# Altium schematic sheet Main.SchDoc
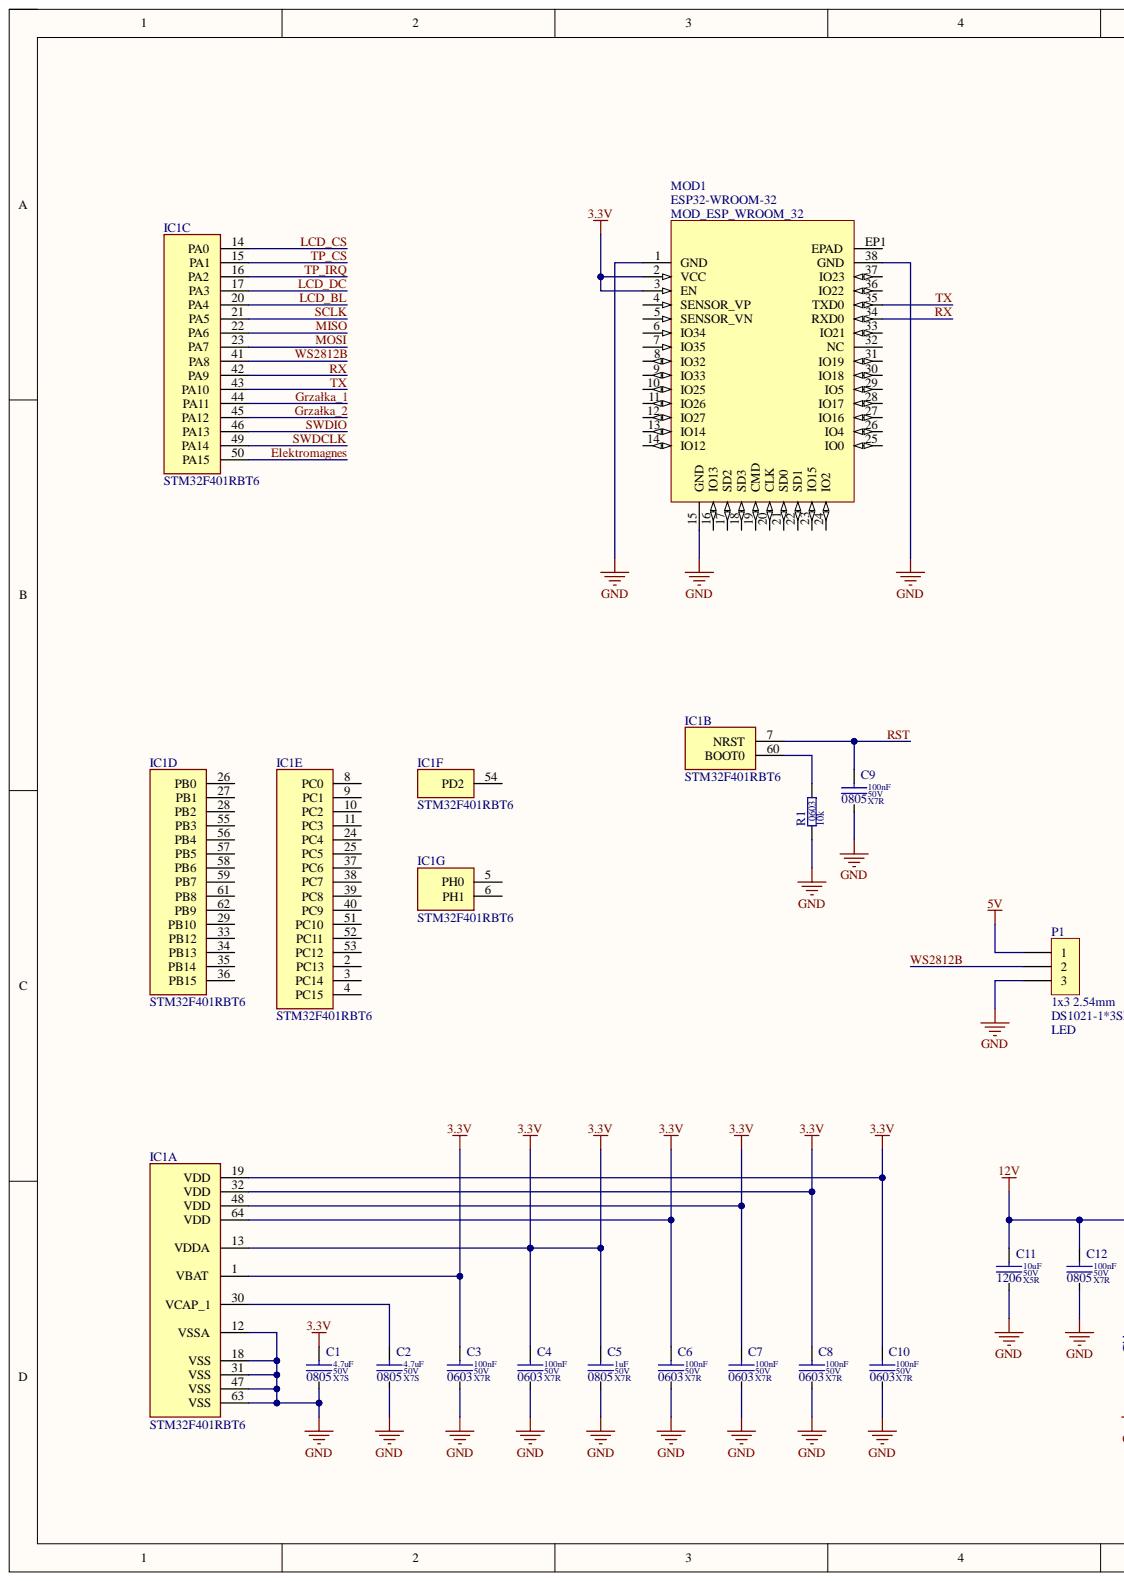
[diagram p0: Main.SchDoc, left]
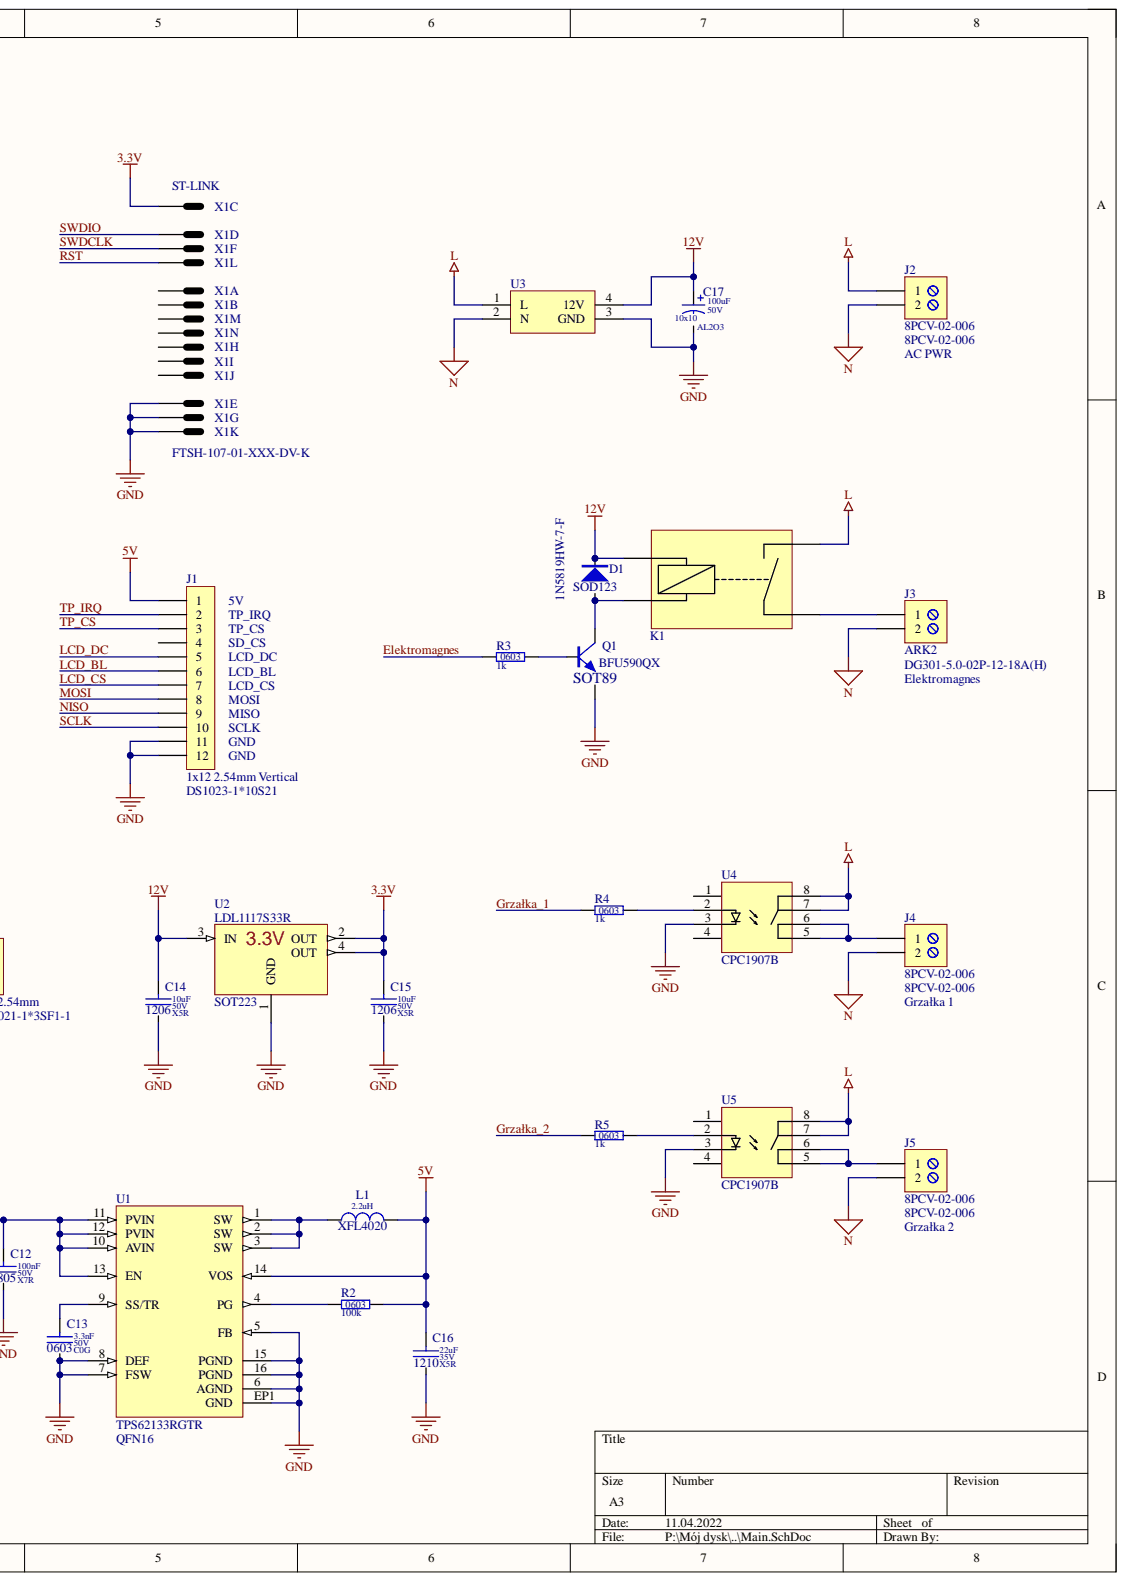
[diagram p1: Main.SchDoc, right]

## Parts
C1  value 4.7uF  Dielectric: X7S  SCH_Footprint: 0805  Voltage: 50V  [C_0805_2012]  (hidden comment: Ceramic X7S)
C2  value 4.7uF  Dielectric: X7S  SCH_Footprint: 0805  Voltage: 50V  [C_0805_2012]  (hidden comment: Ceramic X7S)
C3  value 100nF  Dielectric: X7R  SCH_Footprint: 0603  Voltage: 50V  [C_0603_1608]  (hidden comment: Ceramic X7R)
C4  value 100nF  Dielectric: X7R  SCH_Footprint: 0603  Voltage: 50V  [C_0603_1608]  (hidden comment: Ceramic X7R)
C5  value 1uF  Dielectric: X7R  SCH_Footprint: 0805  Voltage: 50V  [C_0805_2012]  (hidden comment: Ceramic X7R)
C6  value 100nF  Dielectric: X7R  SCH_Footprint: 0603  Voltage: 50V  [C_0603_1608]  (hidden comment: Ceramic X7R)
C7  value 100nF  Dielectric: X7R  SCH_Footprint: 0603  Voltage: 50V  [C_0603_1608]  (hidden comment: Ceramic X7R)
C8  value 100nF  Dielectric: X7R  SCH_Footprint: 0603  Voltage: 50V  [C_0603_1608]  (hidden comment: Ceramic X7R)
C9  value 100nF  Dielectric: X7R  SCH_Footprint: 0805  Voltage: 50V  [C_0805_2012]  (hidden comment: Ceramic X7R)
C10  value 100nF  Dielectric: X7R  SCH_Footprint: 0603  Voltage: 50V  [C_0603_1608]  (hidden comment: Ceramic X7R)
C11  value 10uF  Dielectric: X5R  SCH_Footprint: 1206  Voltage: 50V  [C_1206_3216]  (hidden comment: Ceramic X5R)
C12  value 100nF  Dielectric: X7R  SCH_Footprint: 0805  Voltage: 50V  [C_0805_2012]  (hidden comment: Ceramic X7R)
C13  value 3.3nF  Dielectric: C0G  SCH_Footprint: 0603  Voltage: 50V  [C_0603_1608]  (hidden comment: Ceramic C0G)
C14  value 10uF  Dielectric: X5R  SCH_Footprint: 1206  Voltage: 50V  [C_1206_3216]  (hidden comment: Ceramic X5R)
C15  value 10uF  Dielectric: X5R  SCH_Footprint: 1206  Voltage: 50V  [C_1206_3216]  (hidden comment: Ceramic X5R)
C16  value 22uF  Dielectric: X5R  SCH_Footprint: 1210  Voltage: 35V  [C_1210_3225]  (hidden comment: Ceramic X5R)
C17  value 100uF  Dielectric: AL2O3  SCH_Footprint: 10x10  Voltage: 50V  [CE_D_10x10_SMD]  (hidden comment: Electrolytic Capacitor)
D1  1N5819HW  value 1N5819HW-7-F  Manufacturer Part Number: 1N5819HW-7-F  [SOD123]
IC1  STM32F401RBT6  [LQFP-64]  (parts A,B,C,D,E,F,G)
J1  value DS1023-1*10S21  Manufacturer Part Number: DS1023-1*10S21  [J_HEADER_1x12_2.54_VERTICAL]  (hidden comment: Goldpin female straight)
J2  value 8PCV-02-006  Manufacturer Part Number: 8PCV-02-006  [J_8PCV-02-006]  (hidden comment: 600V 30A connector)
J3  ARK2  value DG301-5.0-02P-12-18A(H)  Manufacturer Part Number: DG301-5.0-02P-12-18A(H)  [J_ARK2]
J4  value 8PCV-02-006  Manufacturer Part Number: 8PCV-02-006  [J_8PCV-02-006]  (hidden comment: 600V 30A connector)
J5  value 8PCV-02-006  Manufacturer Part Number: 8PCV-02-006  [J_8PCV-02-006]  (hidden comment: 600V 30A connector)
K1  FTR-F3AA012E  [FTR-F3AA012E]  (value not drawn)
L1  value 2.2uH  [XFL4020]  (hidden comment: Inductor)
MOD1  value ESP32-WROOM-32  Manufacturer Part Number: ESP32-WROOM-32  [MOD_ESP_WROOM_32]  (hidden comment: 2.4 GHz Wi-Fi-and-Bluetooth combo chip)
P1  value DS1021-1*3SF1-1  Manufacturer Part Number: DS1021-1*3SF1-1  SCH_Footprint: 1x3 2.54mm  [P_HEADER_1x3_2.54]  (hidden comment: Goldpin)
Q1  BFU590QX  SCH_Footprint: SOT89  [SOT89_TO-243AA_N]
R1  value 10k  SCH_Footprint: 0603  [R_0603_1608]  (hidden comment: Resistor)
R2  value 100k  SCH_Footprint: 0603  [R_0603_1608]  (hidden comment: Resistor)
R3  value 1k  SCH_Footprint: 0603  [R_0603_1608]  (hidden comment: Resistor)
R4  value 1k  SCH_Footprint: 0603  [R_0603_1608]  (hidden comment: Resistor)
R5  value 1k  SCH_Footprint: 0603  [R_0603_1608]  (hidden comment: Resistor)
U1  TPS62133RGTR  SCH_Footprint: QFN16  [QFN16_3x3]
U2  LDL1117S33R  SCH_Footprint: SOT223  Voltage: 3.3V  [SOT223_SC73]
U3  IRM-30-12  [IRM-30-12]  (value not drawn)
U4  CPC1907B  [CPC1907B]
U5  CPC1907B  [CPC1907B]
X1  FTSH-107-01-XXX-DV-K  [FTSH-107-01-XXX-DV-K]  (parts A,B,C,D,E,F,G,H,I,J,K,L,M,N)

## Nets
3.3V: C1.1 C3.1 C4.1 C5.1 C6.1 C7.1 C8.1 C10.1 C15.1 IC1.1(VBAT) IC1.13(VDDA) IC1.19(VDD) IC1.32(VDD) IC1.48(VDD) IC1.64(VDD) MOD1.2(VCC) MOD1.3(EN) U2.2(OUT) U2.4(OUT) X1.3
5V: C16.1 J1.1 L1.2 P1.1 R2.2 U1.14(VOS)
12V: C11.1 C12.1 C14.1 C17.1 D1.1 K1.1 U1.10(AVIN) U1.11(PVIN) U1.12(PVIN) U1.13(EN) U2.3(IN) U3.4(12V)
GND: C1.2 C2.2 C3.2 C4.2 C5.2 C6.2 C7.2 C8.2 C9.2 C10.2 C11.2 C12.2 C13.2 C14.2 C15.2 C16.2 C17.2 IC1.12(VSSA) IC1.18(VSS) IC1.31(VSS) IC1.47(VSS) IC1.63(VSS) J1.11 J1.12 MOD1.1(GND) MOD1.15(GND) MOD1.38(GND) P1.3 Q1.1 R1.1 U1.5(FB) U1.6(AGND) U1.7(FSW) U1.8(DEF) U1.15(PGND) U1.16(PGND) U1.EP1(GND) U2.1(GND) U3.3(GND) U4.3 U5.3 X1.5 X1.7 X1.11
L: J2.1 K1.3 U3.1(L) U4.7 U4.8 U5.7 U5.8
N: J2.2 J3.2 J4.2 J5.2 U3.2(N)
Elektromagnes: IC1.50(PA15) R3.1
Grzałka_1: IC1.44(PA11) R4.1
Grzałka_2: IC1.45(PA12) R5.1
LCD_BL: IC1.20(PA4) J1.6
LCD_CS: IC1.14(PA0) J1.7
LCD_DC: IC1.17(PA3) J1.5
MISO: IC1.22(PA6)
MOSI: IC1.23(PA7) J1.8
NISO: J1.9
RST: C9.1 IC1.7(NRST) X1.12
RX: IC1.42(PA9) MOD1.34(RXD0)
SCLK: IC1.21(PA5) J1.10
SWDCLK: IC1.49(PA14) X1.6
SWDIO: IC1.46(PA13) X1.4
TP_CS: IC1.15(PA1) J1.3
TP_IRQ: IC1.16(PA2) J1.2
TX: IC1.43(PA10) MOD1.35(TXD0)
WS2812B: IC1.41(PA8) P1.2
NetC2_1: C2.1 IC1.30(VCAP_1)
NetC13_1: C13.1 U1.9(SS/TR)
NetD1_2: D1.2 K1.2 Q1.2
NetIC1_60: IC1.60(BOOT0) R1.2
NetJ3_1: J3.1 K1.4
NetJ4_1: J4.1 U4.5 U4.6
NetJ5_1: J5.1 U5.5 U5.6
NetL1_1: L1.1 U1.1(SW) U1.2(SW) U1.3(SW)
NetQ1_3: Q1.3 R3.2
NetR2_1: R2.1 U1.4(PG)
NetR4_2: R4.2 U4.2
NetR5_2: R5.2 U5.2

Not connected: IC1 2(PC13) 3(PC14) 4(PC15) 5(PH0) 6(PH1) 8(PC0) 9(PC1) 10(PC2) 11(PC3) 24(PC4) 25(PC5) 26(PB0) 27(PB1) 28(PB2) 29(PB10) 33(PB12) 34(PB13) 35(PB14) 36(PB15) 37(PC6) 38(PC7) 39(PC8) 40(PC9) 51(PC10) 52(PC11) 53(PC12) 54(PD2) 55(PB3) 56(PB4) 57(PB5) 58(PB6) 59(PB7) 61(PB8) 62(PB9); J1 4; MOD1 4(SENSOR_VP) 5(SENSOR_VN) 6(IO34) 7(IO35) 8(IO32) 9(IO33) 10(IO25) 11(IO26) 12(IO27) 13(IO14) 14(IO12) 16(IO13) 17(SD2) 18(SD3) 19(CMD) 20(CLK) 21(SD0) 22(SD1) 23(IO15) 24(IO2) 25(IO0) 26(IO4) 27(IO16) 28(IO17) 29(IO5) 30(IO18) 31(IO19) 32(NC) 33(IO21) 36(IO22) 37(IO23) EP1(EPAD); U4 1 4; U5 1 4; X1 1 2 8 9 10 13 14

## Library data of the parts
C1 C2 = C_4.7uF_50V_X7S_0805_10: Capacitor; Manufacturer: Murata; Manufacturer Part Number: GRM21BC71H475KE11L; Database Table Name: Capacitors; Tolerance (%): 10; Component Kind: Standard; HelpURL: https://datasheet.octopart.com/GRM21BC71H475KE11L-Murata-datasheet-149523453.pdf; Library Name: Library.DBLib; Part Number: C_4.7uF_50V_X7S_0805_10; Supplier 1: TME; Supplier 2: RS Components; Supplier 3: Farnell; Supplier 4: Mouser; Supplier 5: Digi-Key; Supplier 6: LCSC; Supplier Part Number 2: 1726970; Supplier Part Number 3: 3582876; Supplier Part Number 4: 81-GRM21BC71H475KE1L; Supplier Part Number 5: 490-12757-1-ND
C3 C4 C6-C8 C10 = C_100nF_50V_X7R_0603_5: Capacitor; Manufacturer: Samsung; Manufacturer Part Number: CL10B104JB8NNNC; Database Table Name: Capacitors; Tolerance (%): 5; Component Kind: Standard; HelpURL: http://www.tme.eu/pl/Document/4a42202b32dab16128fe107dd69598cc/samsung-chip-cap.pdf; Library Name: Library.DBLib; Part Number: C_100nF_50V_X7R_0603_5; Supplier 1: TME; Supplier 2: RS Components; Supplier 3: Farnell; Supplier 4: Mouser; Supplier 5: Digi-Key; Supplier 6: LCSC; Supplier Part Number 1: CL10B104JB8NNNC; Supplier Part Number 2: 7665455; Supplier Part Number 5: 1276-1033-1-ND; Supplier Part Number 6: C24452
C5 = C_1uF_50V_X7R_0805_10: Capacitor; Manufacturer: AVX; Manufacturer Part Number: 08055C105KAT2A; Database Table Name: Capacitors; Tolerance (%): 10; Component Kind: Standard; HelpURL: https://sigma.octopart.com/147443767/technical_drawing/AVX-08055C105KAT2A.pdf; Library Name: Library.DBLib; Part Number: C_1uF_50V_X7R_0805_10; Supplier 1: TME; Supplier 2: RS Components; Supplier 3: Farnell; Supplier 4: Mouser; Supplier 5: Digi-Key; Supplier 6: LCSC; Supplier Part Number 1: 08055C105KAT2A; Supplier Part Number 2: 1441427; Supplier Part Number 3: 2094043; Supplier Part Number 4: 581-08055C105KAT2A; Supplier Part Number 5: 478-7956-1-ND
C9 C12 = C_100nF_50V_X7R_0805_10: Capacitor; Manufacturer: AVX; Manufacturer Part Number: 08055C104K4T2A; Database Table Name: Capacitors; Tolerance (%): 10; Component Kind: Standard; HelpURL: http://www.tme.eu/pl/Document/943bdb20b23b898c4d4b2ec4eca4f2b6/cauto.pdf; Library Name: Library.DBLib; Part Number: C_100nF_50V_X7R_0805_10; Supplier 1: TME; Supplier 2: RS Components; Supplier 3: Farnell; Supplier 4: Mouser; Supplier 5: Digi-Key; Supplier 6: LCSC; Supplier Part Number 1: 08055C104K4T2A
C11 C14 C15 = C_10uF_50V_X5R_1206_10: Capacitor; Manufacturer: Murata; Manufacturer Part Number: GRM31CR61H106KA12L; Database Table Name: Capacitors; Tolerance (%): 10; Component Kind: Standard; HelpURL: https://datasheet.octopart.com/GRM31CR61H106KA12L-Murata-datasheet-138746367.pdf; Library Name: Library.DBLib; Part Number: C_10uF_50V_X5R_1206_10; Supplier 1: TME; Supplier 2: RS Components; Supplier 3: Farnell; Supplier 4: Mouser; Supplier 5: Digi-Key; Supplier 6: LCSC; Supplier Part Number 1: GRM31CR61H106KA12L; Supplier Part Number 3: 1845762
C13 = C_3.3nF_50V_C0G_0603_5: Capacitor; Manufacturer: Murata; Manufacturer Part Number: GRM1885C1H332JA01D; Database Table Name: Capacitors; Tolerance (%): 5; Component Kind: Standard; HelpURL: https://www.murata.com/~/media/webrenewal/support/library/catalog/products/capacitor/mlcc/c02e.ashx; Library Name: Library.DBLib; Part Number: C_3.3nF_50V_C0G_0603_5; Supplier 1: TME; Supplier 2: RS Components; Supplier 3: Farnell; Supplier 4: Mouser; Supplier 5: Digi-Key; Supplier 6: LCSC; Supplier Part Number 1: GRM1885C1H332JA01D; Supplier Part Number 2: 7235900; Supplier Part Number 3: 2470483; Supplier Part Number 4: 81-GRM1885C1H332JA1D; Supplier Part Number 5: 490-6385-1-ND
C16 = C_22uF_35V_X5R_1210_20: Capacitor; Manufacturer: Taiyo Yuden; Manufacturer Part Number: GMK325BJ226MM-P; Database Table Name: Capacitors; Tolerance (%): 20; Component Kind: Standard; HelpURL: https://datasheet.octopart.com/GMK325BJ226MM-P-Taiyo-Yuden-datasheet-138516745.pdf; Library Name: Library.DBLib; Part Number: C_22uF_35V_X5R_1210_20; Supplier 1: TME; Supplier 2: RS Components; Supplier 3: Farnell; Supplier 4: Mouser; Supplier 5: Digi-Key; Supplier 6: LCSC; Supplier Part Number 2: 103-4209; Supplier Part Number 4: 963-GMK325BJ226MM-P; Supplier Part Number 5: 587-5853-6-ND
C17 = CE_100uF_50V_AL2O3_10x10_20: Polarized Capacitor; Manufacturer: Nichicon; Manufacturer Part Number: UUX1H101MNL1GS; Database Table Name: Capacitors; Tolerance (%): 20; Component Kind: Standard; HelpURL: https://datasheet.octopart.com/UUX1H101MNL1GS-Nichicon-datasheet-62047998.pdf; Library Name: Library.DBLib; Part Number: CE_100uF_50V_AL2O3_10x10_20; Supplier 1: TME; Supplier 2: RS Components; Supplier 3: Farnell; Supplier 4: Mouser; Supplier 5: Digi-Key; Supplier 6: LCSC; Supplier Part Number 1: UUX1H101MNL1GS; Supplier Part Number 2: 7393107; Supplier Part Number 3: 2841943; Supplier Part Number 4: 647-UUX1H101MNL1GS; Supplier Part Number 5: 493-6269-1-ND
D1 = D_1N5819HW_1A_40V_SOD123: Manufacturer: Diodes Inc; Current: 1A; Database Table Name: Diodes; Voltage: 40V; Component Kind: Standard; HelpURL: https://datasheet.octopart.com/1N5819HW-7-F-Diodes-Inc.-datasheet-8332965.pdf; Library Name: Library.DBLib; Part Number: D_1N5819HW_1A_40V_SOD123; SCH_Footprint: SOD123; Supplier 1: TME; Supplier 2: RS Components; Supplier 3: Farnell; Supplier 4: Mouser; Supplier 5: Digi-Key; Supplier 6: LCSC; Supplier Part Number 1: 1N5819HW-7-F; Supplier Part Number 2: 7082197; Supplier Part Number 3: 1773475; Supplier Part Number 4: 621-1N5819HW-F; Supplier Part Number 5: 1N5819HW-FDICT-ND
IC1 = STM32F401RBT6: ARM Microcontroller; Component Kind: Standard; Library Name: Components.SchLib
J1 = J_HEADER_1x12_2.54_VERTICAL: Pin Header; Manufacturer: Connfly; Database Table Name: Connectors; SCH_Footprint: 1x12 2.54mm Vertical; Component Kind: Standard; HelpURL: https://www.tme.eu/Document/efd0203e2d3a140765df98191085ea88/DS1023.pdf; Library Name: Library.DBLib; Part Number: J_HEADER_1x12_2.54_VERTICAL; Supplier 1: TME; Supplier 2: RS Components; Supplier 3: Farnell; Supplier 4: Mouser; Supplier 5: Digi-Key; Supplier 6: LCSC; Supplier Part Number 1: ZL262-10SG
J2 J4 J5 = J_8PCV-02-006: ARK Screw terminal, 2-Pin; Manufacturer: TE Connectivity; Database Table Name: Connectors; Component Kind: Standard; HelpURL: http://www.mouser.com/ds/2/418/NG_CD_6-1437657-3_K4-669783.pdf; Library Name: Library.DBLib; Part Number: J_8PCV-02-006; SCH_Footprint: 8PCV-02-006; Supplier 1: TME; Supplier 2: RS Components; Supplier 3: Farnell; Supplier 4: Mouser; Supplier 5: Digi-Key; Supplier 6: LCSC; Supplier Part Number 2: 7176637; Supplier Part Number 4: 571-514376577; Supplier Part Number 5: A98460-ND
J3 = J_TB-5.0-P-2P: ARK Screw terminal, 2-Pin; Manufacturer: DEGSON ELECTRONICS; Database Table Name: Connectors; Component Kind: Standard; HelpURL: https://datasheet.octopart.com/DG301-5.0-03P-12-18A%28H%29-Degson-datasheet-17801202.pdf; Library Name: Library.DBLib; Part Number: J_TB-5.0-P-2P; SCH_Footprint: ARK2; Supplier 1: TME; Supplier 2: RS Components; Supplier 3: Farnell; Supplier 4: Mouser; Supplier 5: Digi-Key; Supplier 6: LCSC; Supplier Part Number 1: DG301-5.0-2/6B
L1 = L_2.2uH_8A_XFL4020: Induction; Manufacturer: Coilcraft; Manufacturer Part Number: XFL4020-222MEC; Current: 8A; Database Table Name: Inductors; Resistance: 23.5mR; Component Kind: Standard; HelpURL: https://pl.mouser.com/datasheet/2/597/xfl4020-270756.pdf; Library Name: Library.DBLib; Part Number: L_2.2uH_8A_XFL4020; SCH_Footprint: XFL4020; Supplier 1: TME; Supplier 2: RS Components; Supplier 3: Farnell; Supplier 4: Mouser; Supplier 5: Digi-Key; Supplier 6: LCSC; Supplier 7: Coilcraft; Supplier Part Number 3: 2289216; Supplier Part Number 4: 994-XFL4020-222MEC; Supplier Part Number 7: XFL4020-222MEC
MOD1 = MOD_ESP32-WROOM-32: Manufacturer: ESPRESSIF; Database Table Name: Modules; Component Kind: Standard; HelpURL: https://www.espressif.com/sites/default/files/documentation/esp32-wroom-32d_esp32-wroom-32u_datasheet_en.pdf; Library Name: Library.DBLib; Part Number: MOD_ESP32-WROOM-32; SCH_Footprint: MOD_ESP_WROOM_32; Supplier 1: TME; Supplier 2: RS Components; Supplier 3: Farnell; Supplier 4: Mouser; Supplier 5: Digi-Key; Supplier 6: LCSC; Supplier Part Number 1: ESP32-WROOM-32; Supplier Part Number 2: 169-7594; Supplier Part Number 4: 356-ESP-WROOM-32; Supplier Part Number 5: 1904-1010-1-ND
P1 = P_HEADER_1x3_2.54: Pin Header; Manufacturer: Connfly; Database Table Name: Connectors; Component Kind: Standard; HelpURL: http://www.tme.eu/en/Document/cc301e58a5da78cb543a94b5a5b7f06a/DS1021.pdf; Library Name: Library.DBLib; Part Number: P_HEADER_1x3_2.54; Supplier 1: TME; Supplier 2: RS Components; Supplier 3: Farnell; Supplier 4: Mouser; Supplier 5: Digi-Key; Supplier 6: LCSC; Supplier Part Number 1: ZL201-03G
Q1 = QNP_BFU590QX: Manufacturer: NXP; Current: 200mA; Database Table Name: Transistors; Type: NPN; Voltage: 12V; Component Kind: Standard; HelpURL: https://www.nxp.com/docs/en/data-sheet/BFU590Q.pdf; Library Name: Library.DBLib; Manufacturer Part Number: BFU590QX; Part Number: QNP_BFU590QX; Supplier 1: TME; Supplier 2: RS Components; Supplier 3: Farnell; Supplier 4: Mouser; Supplier 5: Digi-Key; Supplier 6: LCSC; Supplier Part Number 3: 2776273; Supplier Part Number 4: 771-BFU590QX; Supplier Part Number 5: 568-11522-1-ND; Value: BFU590QX
R1 = R_10k_0603_1: Manufacturer: Vishay; Manufacturer Part Number: CRCW060310K0FKTABC; Database Table Name: Resistors; Power: 100mW; Tolerance: 1%; Voltage: 75V; Component Kind: Standard; HelpURL: http://www.tme.eu/pl/Document/622e28308c06d160637f2b14751ba16b/Data%20Sheet%20CRCW_BCe3.pdf; Library Name: Library.DBLib; Part Number: R_10k_0603_1; Supplier 1: TME; Supplier 2: RS Components; Supplier 3: Farnell; Supplier 4: Mouser; Supplier 5: Digi-Key; Supplier 6: LCSC; Supplier Part Number 1: CRCW060310K0FKTABC; Supplier Part Number 4: 667-ERJ-3EKF1002V; Supplier Part Number 5: P10KGCT-ND
R2 = R_100k_0603_1: Manufacturer: Royalohm; Manufacturer Part Number: 0603SAF1003T5E; Database Table Name: Resistors; Power: 100mW; Tolerance: 1%; Voltage: 50V; Component Kind: Standard; HelpURL: http://www.tme.eu/pl/Document/b315665a56acbc42df513c99b390ad98/ROYALOHM-THICKFILM.pdf; Library Name: Library.DBLib; Part Number: R_100k_0603_1; Supplier 1: TME; Supplier 2: RS Components; Supplier 3: Farnell; Supplier 4: Mouser; Supplier 5: Digi-Key; Supplier 6: LCSC; Supplier Part Number 1: SMD0603-100K-1%; Supplier Part Number 4: 667-ERJ-3EKF1003V; Supplier Part Number 5: P100KHCT-ND
R3-R5 = R_1k_0603_1: Manufacturer: Vishay; Manufacturer Part Number: CRCW06031K00FKEA; Database Table Name: Resistors; Power: 100mW; Tolerance: 1%; Voltage: 75V; Component Kind: Standard; HelpURL: http://www.farnell.com/datasheets/2310790.pdf?_ga=2.256888843.1690599162.1548417389-1379097396.1542296996&_gac=1.262631934.1547230258.Cj0KCQiAmuHhBRD0ARIsAFWyPwhX8HcvXnM3tA0ZBodZLzDIjH2ZxLpjT5LWo9bad_HPRbU8LfPq44UaAhEwEALw_wcB; Library Name: Library.DBLib; Part Number: R_1k_0603_1; Supplier 1: TME; Supplier 2: RS Components; Supplier 3: Farnell; Supplier 4: Mouser; Supplier 5: Digi-Key; Supplier 6: LCSC; Supplier Part Number 2: 678-9875P; Supplier Part Number 3: 1469740; Supplier Part Number 4: 71-CRCW0603-1.0K-E3; Supplier Part Number 5: 541-1.00KHCT-ND
U1 = VS_TPS62133RGTR: 3-17V 3A Step-Down Converter with DCS-Control in 3x3 QFN; Manufacturer: Texas Instruments; Database Table Name: Switching regulators; Frequency (kHz): 2500; Imax (A): 3; Iswitch (A): 0; Topology: Buck; Vin max (V): 17; Vout max (V): 5; Component Kind: Standard; HelpURL: https://www.ti.com/lit/ds/symlink/tps62133.pdf?ts=1622201106793&ref_url=https%253A%252F%252Fwww.ti.com%252Fproduct%252FTPS62133%253FHQS%253DTI-null-null-octopart-df-pf-null-wwe; Library Name: Library.DBLib; Manufacturer Part Number: TPS62133RGTR; Part Number: VS_TPS62133RGTR; Supplier 1: TME; Supplier 2: RS Components; Supplier 3: Farnell; Supplier 4: Mouser; Supplier 5: Digi-Key; Supplier 6: LCSC; Supplier Part Number 3: 3122975; Supplier Part Number 4: 595-TPS62133RGTR; Supplier Part Number 5: 296-29937-1-ND; Supplier Part Number 6: C73973; Value: TPS62133RGTR; Vin min (V): 3; Vout min (V): 5
U2 = VL_LDL1117S33R: typical configuration; Manufacturer: STMicroelectronics; Current: 1.2A; Database Table Name: Voltage Regulators; Component Kind: Standard; HelpURL: https://www.st.com/resource/en/datasheet/ldl1117.pdf; Library Name: Library.DBLib; Manufacturer Part Number: LDL1117S33R; Part Number: VL_LDL1117S33R; Supplier 1: TME; Supplier 2: RS Components; Supplier 3: Farnell; Supplier 4: Mouser; Supplier 5: Digi-Key; Supplier 6: LCSC; Supplier Part Number 1: LDL1117S33R; Supplier Part Number 3: 2729708; Supplier Part Number 4: 511-LDL1117S33R; Supplier Part Number 5: 497-17239-1-ND; Value: LDL1117S33R
X1 = FTSH-107-01-XXX-DV-K: IDC 14pin male connector; Component Kind: Standard; Library Name: Connectors.SchLib
K1 = FTR-F3AA012E: Component Kind: Standard; Library Name: SchLib.SchLib
U3 = IRM-30-12: Component Kind: Standard; Library Name: SchLib.SchLib
U4 U5 = CPC1907B: Component Kind: Standard; Library Name: SchLib.SchLib
